# Altium schematic sheet Sheet4.SchDoc(Sheet4)
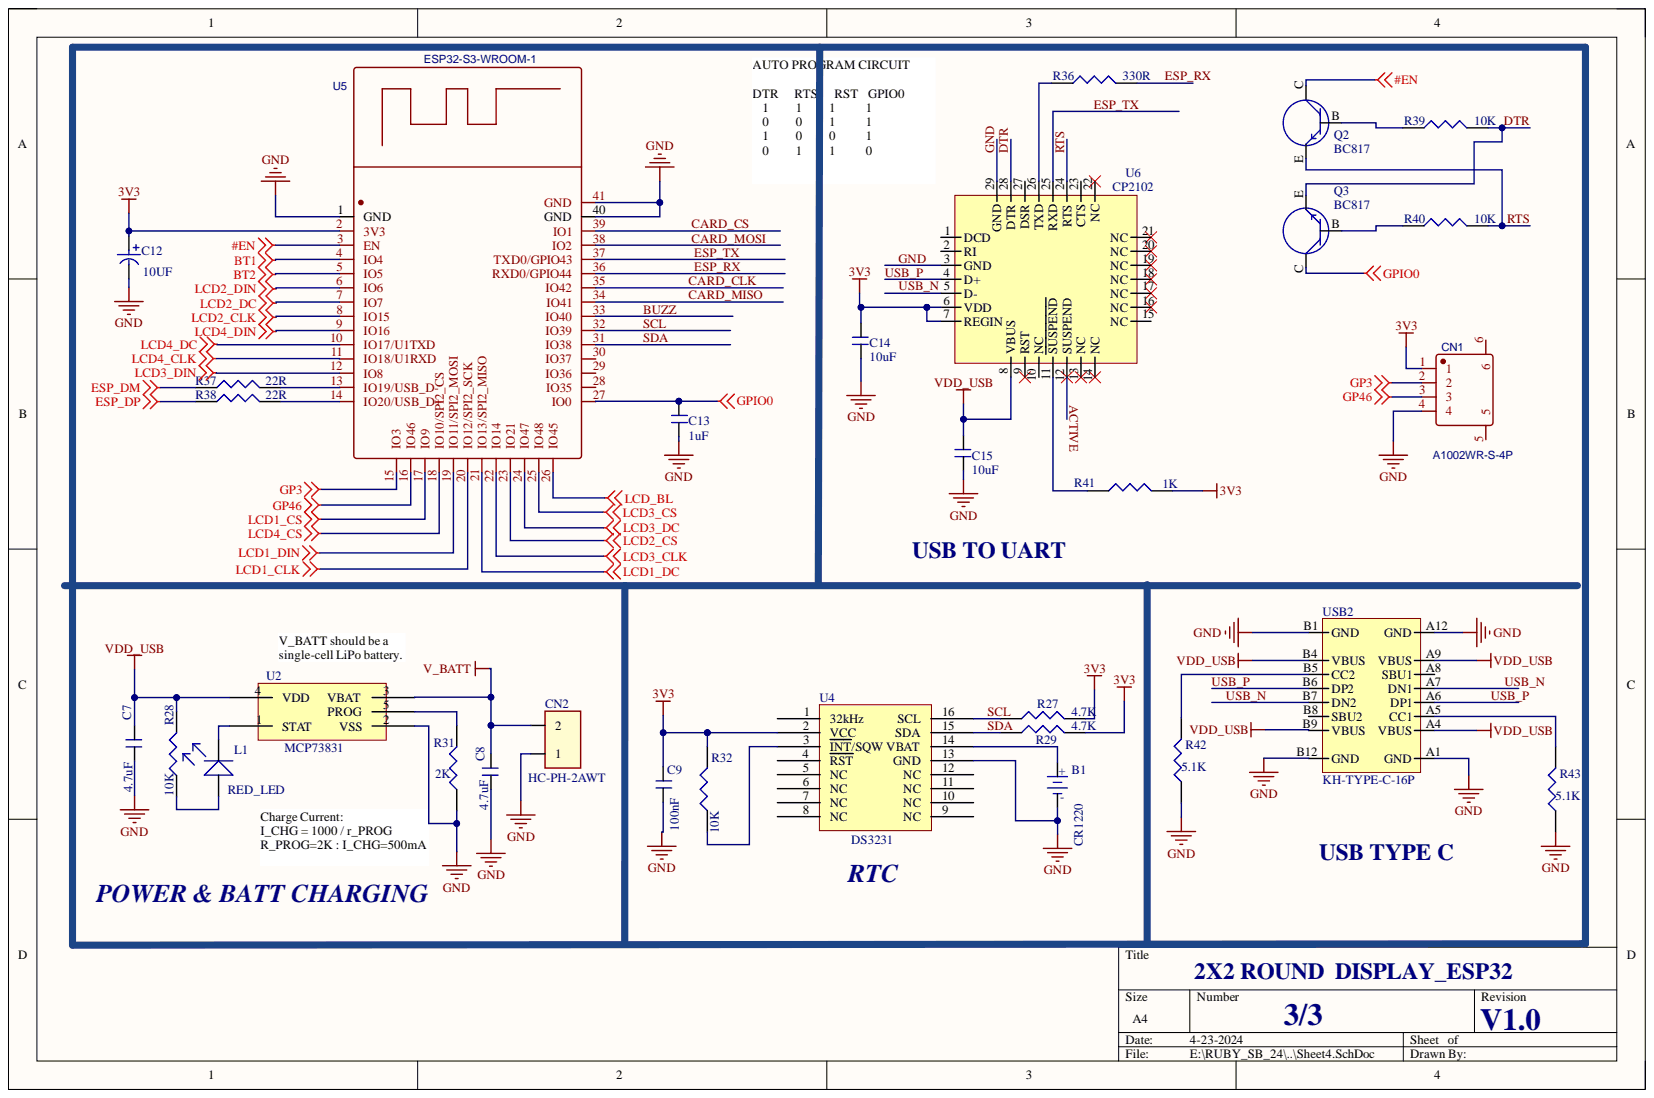
[diagram p0: Sheet4.SchDoc]

## Parts
B1  CR1220  [COIN_CELL_HOLD]
C7  4.7uF  [C_0603]
C8  4.7uF  [C_0603]
C9  100nF  [C_0603]
C12  10UF  [C_0603]
C13  1uF  [C_0603]
C14  10uF  [C_0603]
C15  10uF  [C_0603]
CN1  A1002WR-S-4P  [SH 1x4P 1mm]
CN2  HC-PH-2AWT  [JST2P_P2.0mm]
L1  RED_LED  [LED_0603]
Q2  BC817  [SOT23]
Q3  BC817  [SOT23]
R27  4.7K  [R_0603]
R28  10K  [R_0603]
R29  4.7K  [R_0603]
R31  2K  [R_0603]
R32  10K  [R_0603]
R36  330R  [R_0603]
R37  22R  [R_0603]
R38  22R  [R_0603]
R39  10K  [R_0603]
R40  10K  [R_0603]
R41  1K  [R_0603]
R42  5.1K  [R_0603]
R43  5.1K  [R_0603]
U2  MCP73831  [SOT23-5]
U4  DS3231  [SO_16]
U5  ESP32-S3-WROOM-1  [ESP32-S3-WROOM1]
U6  CP2102  [CP2102]
USB2  KH-TYPE-C-16P  [USB TYPE _C]

## Nets
3V3: C9.2 C12.1 C14.1 CN1.1 R27.2 R29.2 R32.2 R41.2 U4.2(VCC) U5.2(3V3) U6.6(VDD) U6.7(REGIN)
#EN: Q2.C U5.3(EN)
BT1: U5.4(IO4)
BT2: U5.5(IO5)
ESP_DM: R37.1
ESP_DP: R38.1
GP3: CN1.2 U5.15(IO3)
GP46: CN1.3 U5.16(IO46)
GPIO0: C13.2 Q3.C U5.27(IO0)
LCD1_CLK: U5.20(IO12/SPI2_SCK)
LCD1_CS: U5.17(IO9)
LCD1_DC: U5.21(IO13/SPI2_MISO)
LCD1_DIN: U5.19(IO11/SPI2_MOSI)
LCD2_CLK: U5.8(IO15)
LCD2_CS: U5.23(IO21)
LCD2_DC: U5.7(IO7)
LCD2_DIN: U5.6(IO6)
LCD3_CLK: U5.22(IO14)
LCD3_CS: U5.25(IO48)
LCD3_DC: U5.24(IO47)
LCD3_DIN: U5.12(IO8)
LCD4_CLK: U5.11(IO18/U1RXD)
LCD4_CS: U5.18(IO10/SPI2_CS)
LCD4_DC: U5.10(IO17/U1TXD)
LCD4_DIN: U5.9(IO16)
LCD_BL: U5.26(IO45)
V_BATT: C8.1 CN2.2 U2.3(VBAT)
VDD_USB: C7.1 C15.1 R28.2 U2.4(VDD) U6.8(VBUS) USB2.A4(VBUS) USB2.A9(VBUS) USB2.B4(VBUS) USB2.B9(VBUS)
ACTIVE: U6.12(SUSPEND)
BUZZ: U5.33(IO40)
CARD_CLK: U5.35(IO42)
CARD_CS: U5.39(IO1)
CARD_MISO: U5.34(IO41)
CARD_MOSI: U5.38(IO2)
DTR: Q3.E R39.2 U6.28(DTR)
ESP_RX: R36.2 U5.36(RXD0/GPIO44)
ESP_TX: U5.37(TXD0/GPIO43) U6.25(RXD)
GND: B1.K C7.2 C8.2 C9.1 C12.2 C13.1 C14.2 C15.2 CN1.4 CN2.1 R31.1 R42.1 R43.1 U2.2(VSS) U4.13(GND) U5.1(GND) U5.40(GND) U5.41(GND) U6.3(GND) U6.29(GND) USB2.A1(GND) USB2.A12(GND) USB2.B1(GND) USB2.B12(GND)
RTS: Q2.E R40.2 U6.24(RTS)
SCL: R27.1 U4.16(SCL) U5.32(IO39)
SDA: R29.1 U4.15(SDA) U5.31(IO38)
USB_N: U6.5(D-) USB2.A7(DN1) USB2.B7(DN2)
USB_P: U6.4(D+) USB2.A6(DP1) USB2.B6(DP2)
NetB1_A: B1.A U4.14(VBAT)
NetL1_A: L1.A R28.1
NetL1_K: L1.K U2.1(STAT)
NetQ2_B: Q2.B R39.1
NetQ3_B: Q3.B R40.1
NetR31_2: R31.2 U2.5(PROG)
NetR32_1: R32.1 U4.3(INT/SQW)
NetR36_1: R36.1 U6.26(TXD)
NetR37_2: R37.2 U5.13(IO19/USB_D-)
NetR38_2: R38.2 U5.14(IO20/USB_D+)
NetR41_1: R41.1 U6.11(SUSPEND)
NetR42_2: R42.2 USB2.B5(CC2)
NetR43_2: R43.2 USB2.A5(CC1)

Not connected: CN1 5 6; U4 1(32kHz) 4(RST) 5(NC) 6(NC) 7(NC) 8(NC) 9(NC) 10(NC) 11(NC) 12(NC); U5 28(IO35) 29(IO36) 30(IO37); U6 1(DCD) 2(RI) 9(RST) 10(NC) 13(NC) 14(NC) 15(NC) 16(NC) 17(NC) 18(NC) 19(NC) 20(NC) 21(NC) 22(NC) 23(CTS) 27(DSR); USB2 A8(SBU1) B8(SBU2)

## Library data of the parts
B1 = BATTERY: Component Kind: Standard; Library Name: dxp_pasl_lib.IntLib
C7-C9 C13-C15 = CAP_NONPOLAR: Component Kind: Standard; Library Name: dxp_pasl_lib.IntLib
C12 = CAP_ELE: Component Kind: Standard; Library Name: MIX.SchLib
CN1 = A1002WR-S-4P: Manufacturer: CJT(长江连接器); Contributor: LCSC; Component Kind: Standard; JLCPCB Part Class: Extended Part; Manufacturer Part: A1002WR-S-4P; spicePre: C; spiceSymbolName: A1002WR-S-4P; Supplier: LCSC; Supplier Part: C239522
CN2 = CON2: Component Kind: Standard; Library Name: dxp_pasl_lib.IntLib
L1 = LED: Component Kind: Standard; Library Name: dxp_pasl_lib.IntLib
Q2 Q3 = TRANSISTER_NPN: Component Kind: Standard; Library Name: dxp_pasl_lib.IntLib
R27-R29 R31 R32 R36-R43 = RES: Component Kind: Standard; Library Name: dxp_pasl_lib.IntLib
U4 = DS3231_1: Extremely Accurate I2C-Integrated  RTC; Component Kind: Standard; Library Name: dxp_pasl_lib.IntLib
U5 = ESP32-S3-WROOM-1(N16): BOM_Manufacturer: ESPRESSIF(乐鑫); BOM_Manufacturer Part: ESP32-S3-WROOM-1-N16; Contributor: lcsc; BOM_JLCPCB Part Class: Extended Part; BOM_Supplier: LCSC; BOM_Supplier Part: C2913199; Component Kind: Standard; link: https://atta.szlcsc.com/upload/public/pdf/source/20220628/A2AC12B3C87735CAEB7EBE2DBE80936C.pdf; spicePre: U; spiceSymbolName: ESP32-S3-WROOM-1(N16)
U6 = CP2102_1: QFN, 28-Leads, Body 5.0x5.0mm (max), Pitch 0.50mm, IPC Medium Density; Component Kind: Standard; Library Name: dxp_pasl_lib.IntLib
USB2 = USB TYPE-C KH-TYPE-C-16P: 3A 1 Surface Mount 16 Female -40?~+85? Type-C SMD USB Connectors ROHS #  C709357; Component Kind: Standard; ComponentLink2Description: New link; ComponentLink2URL: https://lcsc.com/product-detail/USB-Connectors_Shenzhen-Kinghelm-Elec-KH-TYPE-C-16P_C709357.html; Supplier 1: LCSC; Supplier Part Number 1: C165948; Library Name: dxp_pasl_lib.IntLib
U2 = MCP73831: Component Kind: Standard; Library Name: dxp_pasl_lib.IntLib
